# Altium schematic sheet Alimentation.SchDoc(Alimentation)
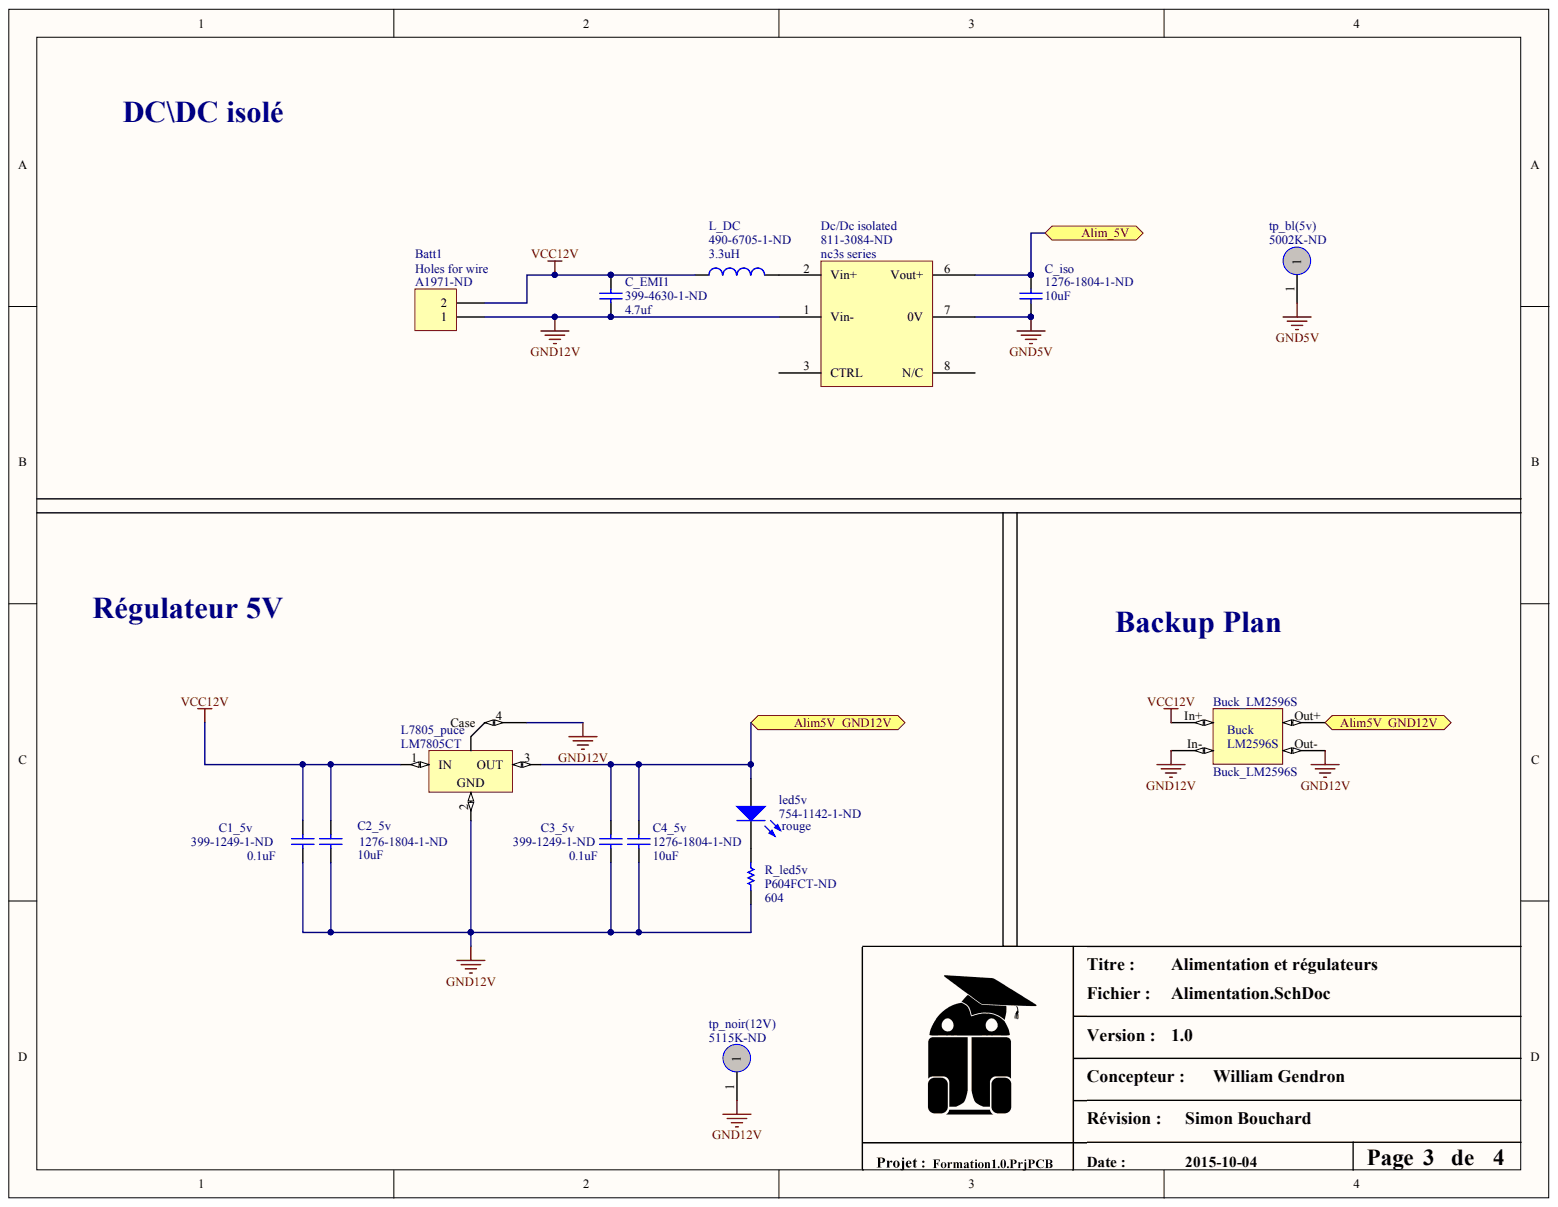
[diagram p0: Alimentation.SchDoc]

## Parts
Batt1  value Holes for wire  Supplier Part Number 1: A1971-ND  [18awg_wire_patch]  (hidden comment: Batt1- A1921-ND)
Buck_LM2596S  Buck_LM2596S  [Buck_LM2596S]
C1_5v  value 0.1uF  Supplier Part Number 1: 399-1249-1-ND  [c1206]  (hidden comment: 0.1uf - 399-1249-1-ND)
C2_5v  value 10uF  Supplier Part Number 1: 1276-1804-1-ND  [c1206]  (hidden comment: 10uf  - 1276-1804-1-ND)
C3_5v  value 0.1uF  Supplier Part Number 1: 399-1249-1-ND  [c1206]  (hidden comment: 0.1uf - 399-1249-1-ND)
C4_5v  value 10uF  Supplier Part Number 1: 1276-1804-1-ND  [c1206]  (hidden comment: 10uf - 1276-1804-1-ND)
C_EMI1  value 4.7uf  Supplier Part Number 1: 399-4630-1-ND  [8-1206]  (hidden comment: 10uf - 1276-1804-1-ND)
C_iso  10uF - 1276-1804-1-ND  value 10uF  Supplier Part Number 1: 1276-1804-1-ND  [c1206]
Dc/Dc_isolated  nc3s series  Supplier Part Number 1: 811-3084-ND  [NCS3S]
L7805_puce  LM7805CT  [to220_3pins_bent]
L_DC  3.3uH - 490-6705-1-ND  value 3.3uH  Supplier Part Number 1: 490-6705-1-ND  [8-1206]
led5v  rouge  Supplier Part Number 1: 754-1142-1-ND  [c1206]
R_led5v  value 604  Supplier Part Number 1: P604FCT-ND  [c1206]  (hidden comment: 604ohms - P604FCT-ND)
tp_bl(5v)  Supplier Part Number 1: 5002K-ND  [testpoint_gnd]  (hidden comment: testpoint_blanc - 5002K-ND)
tp_noir(12V)  Supplier Part Number 1: 5115K-ND  [testpoint_gnd]  (hidden comment: testpoint_noir - 5115K-ND)

## Ports
ALIM5V_GND12V, ALIM_5V

## Nets
GND5V: C_iso.1 Dc/Dc_isolated.7(0V) tp_bl(5v).1
GND12V: Batt1.1 Buck_LM2596S.In- Buck_LM2596S.Out- C1_5v.1 C2_5v.1 C3_5v.1 C4_5v.1 C_EMI1.1 Dc/Dc_isolated.1(Vin-) L7805_puce.2 L7805_puce.4(Case) R_led5v.1 tp_noir(12V).1
VCC12V: Batt1.2 Buck_LM2596S.In+ C1_5v.2 C2_5v.2 C_EMI1.2 L7805_puce.1(IN) L_DC.1
NetBuck_LM2596S_Out+: Buck_LM2596S.Out+ C3_5v.2 C4_5v.2 L7805_puce.3(OUT) led5v.1 ; port ALIM5V_GND12V
NetC_iso_2: C_iso.2 Dc/Dc_isolated.6(Vout+) ; port ALIM_5V
NetDc/Dc isolated_2: Dc/Dc_isolated.2(Vin+) L_DC.2
Netled5v_2: led5v.2 R_led5v.2

Not connected: Dc/Dc_isolated 3(CTRL) 8(N/C)

## Library data of the parts
Batt1 = Header 2H: Header, 2-Pin, Right Angle; Complementary Part 1: A31320-ND; Component Kind: Standard; Library Name: Miscellaneous Connectors.IntLib; Signal Integrity: Connector; Supplier 1: Digi-Key
C1_5v C2_5v C3_5v C4_5v C_EMI1 C_iso = Cap: Capacitor; Component Kind: Standard; Library Name: Miscellaneous Devices.IntLib; Signal Integrity: Cap; Simulation: CAP; Supplier 1: Digi-Key
Dc/Dc_isolated = DCDC5V: Component Kind: Standard; Library Name: dcdc - ncs3s.SchLib; Supplier 1: Digi-Key
L_DC = Inductor: Component Kind: Standard; Description: Inductor; Library Name: Miscellaneous Devices.IntLib; Signal Integrity: Inductor; Simulation: INDUCTOR; Supplier 1: Digi-Key
led5v = LED2: Typical RED, GREEN, YELLOW, AMBER GaAs LED; Component Kind: Standard; Library Name: Miscellaneous Devices.IntLib; Simulation: LED2; Supplier 1: Digi-Key
R_led5v = Res1: Resistor; Component Kind: Standard; Library Name: Miscellaneous Devices.IntLib; Signal Integrity: Res; Simulation: RESISTOR; Supplier 1: Digi-Key
tp_bl(5v) = testpoint_blanc: Component Kind: Standard; Library Name: testpoint.SchLib; Supplier 1: Digi-Key
tp_noir(12V) = testpoint_noir: Component Kind: Standard; Library Name: testpoint.SchLib; Supplier 1: Digi-Key
Buck_LM2596S = Buck_LM2596S: Component Kind: Standard; Library Name: Buck_LM2596S.SchLib
L7805_puce = LM7805CT: Component Kind: Standard; Supplier 1: Digi-Key; Supplier Part Number 1: LM7805CT-ND; Library Name: regulateur.SchLib
